# Altium schematic sheet InitialAmp.SchDoc(InitialAmp)
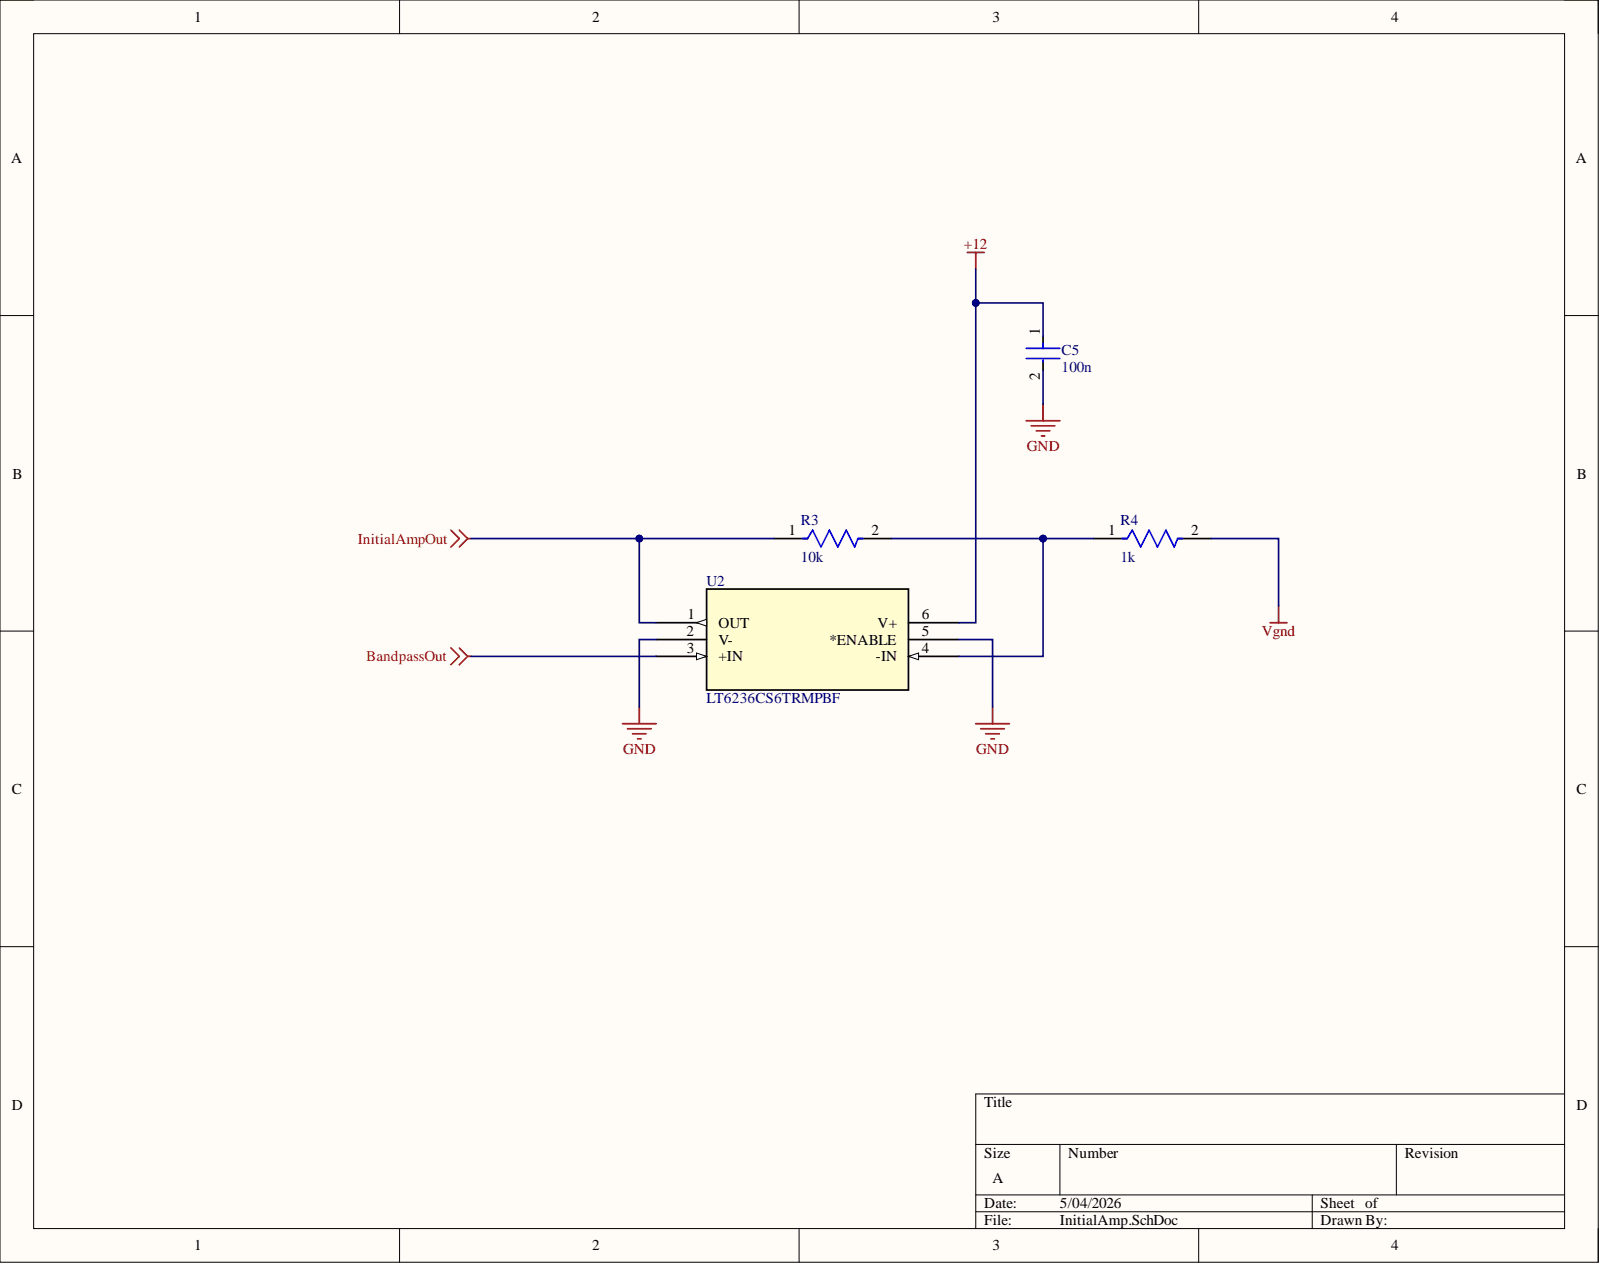
[diagram p0: InitialAmp.SchDoc]

## Parts
C5  100n
R3  10k
R4  1k
U2  LT6236CS6TRMPBF

## Nets
+12: C5.1 U2.6(V+)
BandpassOut: U2.3(+IN)
GND: C5.2 U2.2(V-) U2.5(*ENABLE)
InitialAmpOut: R3.1 U2.1(OUT)
Vgnd: R4.2
NetR3_2: R3.2 R4.1 U2.4(-IN)

## Library data of the parts
C5 = CMP-06035-028588-1: Chip Multilayer Ceramic Capacitors for General Purpose, 1206, 0.10uF, C0G, 30ppm/°C, 5%, 50V; Component Kind: Standard; Library Name: Altium Content Vault
R3 = CMP-2001-03215-2: 10kΩ ±0.5% 0.1W 1/10W Chip Resistor 0805 (2012 Metric) Thin Film; Max Power Dissipation: 100mW; Resistance: 10kR; Temperature Coefficient: 25ppm/°C; Voltage Rating: 100V; Case Code (Imperial): 0805; Case Code (Metric): 2012; Case/Package: 0805; Component Kind: Standard; Depth: 1.25mm; Height: 0.4mm; Lead Free: Lead Free; Length: 2mm; Library Name: Altium Content Vault; Max Operating Temperature: 125°C; Min Operating Temperature: -55°C; Number of Terminations: 2; Package Quantity: 1; Packaging: Tape and Reel; Power Rating: 100mW; Resistance Tolerance: 0.5%; Resistor Type: Thin Film; RoHS Compliant: Yes; Series: RR; Size Code: 0805; Technology: THIN FILM; Termination: SMD/SMT; Tolerance: 0.5%; Voltage Rating (DC): 100V; Weight: 0.000194oz; Width: 1.25mm
R4 = CMP-2001-03210-2: 1kΩ ±0.5% 0.1W 1/10W Chip Resistor 0805 (2012 Metric) Thin Film; Max Power Dissipation: 100mW; Resistance: 1kR; Temperature Coefficient: 25ppm/°C; Voltage Rating: 100V; Case Code (Imperial): 0805; Case Code (Metric): 2012; Case/Package: 0805; Component Kind: Standard; Depth: 1.25mm; Height: 0.4mm; Lead Free: Lead Free; Length: 2mm; Library Name: Altium Content Vault; Max Operating Temperature: 125°C; Min Operating Temperature: -55°C; Number of Terminations: 2; Package Quantity: 1; Packaging: Tape and Reel; Power Rating: 100mW; Resistance Tolerance: 0.5%; Resistor Type: Thin Film; RoHS Compliant: Yes; Series: RR; Size Code: 0805; Technology: THIN FILM; Termination: SMD/SMT; Tolerance: 0.5%; Voltage Rating (DC): 100V; Width: 1.25mm
U2 = CMP-014-00002-1: Rail-to-Rail Output 215MHz, 1.1nV/âˆšHz Op Amp/ SAR ADC Driver; Manufacturer_Name: Analog Devices Inc; Manufacturer_Part_Number: LT6236CS6#TRMPBF; Component Kind: Standard; Datasheet: https://www.analog.com/media/en/technical-documentation/data-sheets/623637fb.pdf; Design Item ID: LT6236CS6TRMPBF; RefDes: RefDes; Source: LT6236CS6TRMPBF.SchLib; Type: Type; Copyright: Copyright (C) 2026 Ultra Librarian. All rights reserved.; Library Name: James Brasfield
